# Altium schematic sheet XIMUF407.SchDoc(XIMUF407)
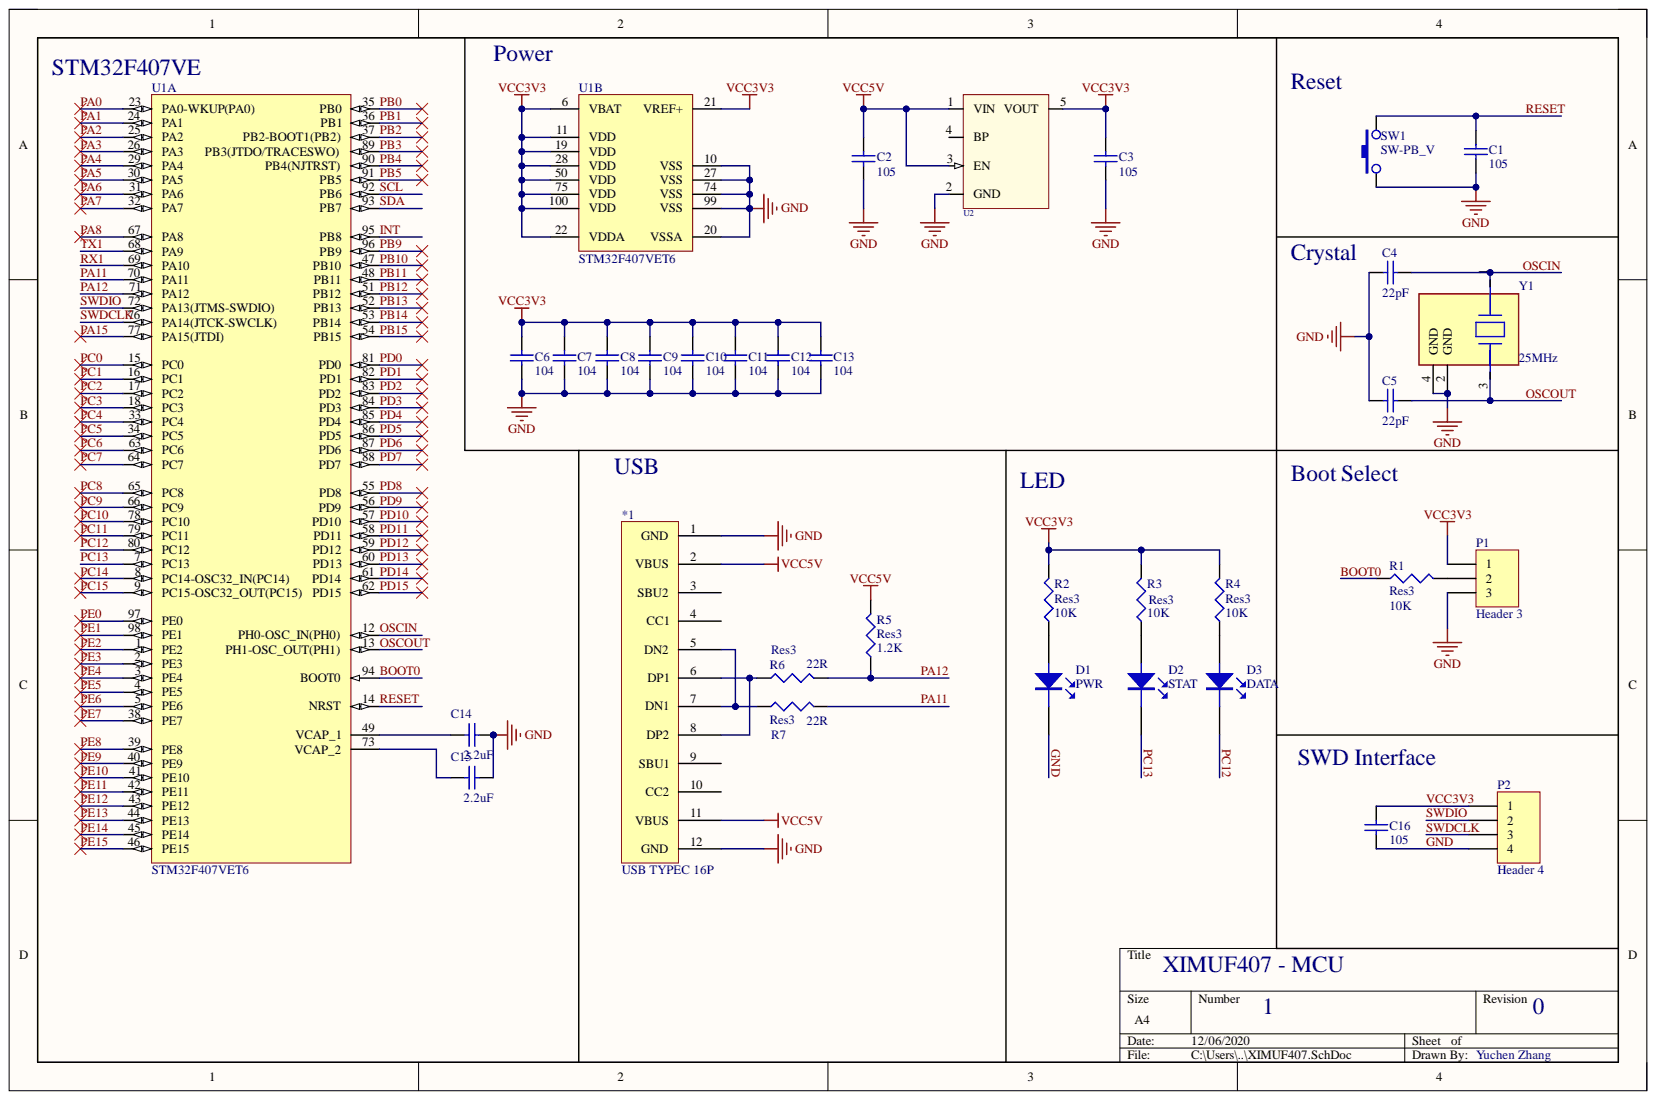
[diagram p0: XIMUF407.SchDoc]

## Parts
*1  USB TYPEC 16P  [New footprint 1]
C1  105  [C 0603]
C2  105  [C 0603]
C3  105  [C 0603]
C4  22pF  [C 0603]
C5  22pF  [C 0603]
C6  104  [C 0603]
C7  104  [C 0603]
C8  104  [C 0603]
C9  104  [C 0603]
C10  104  [C 0603]
C11  104  [C 0603]
C12  104  [C 0603]
C13  104  [C 0603]
C14  2.2uF  [C 0603]
C15  2.2uF  [C 0603]
C16  105  [C 0603]
D1  PWR  [LED 0805G]
D2  STAT  [LED 0805G]
D3  DATA  [LED 0805G]
P1  Header 3  [HDR1X3]
P2  Header 4  [HDR1X4]
R1  Res3  value 10K  [J1-0603]
R2  Res3  value 10K  [J1-0603]
R3  Res3  value 10K  [J1-0603]
R4  Res3  value 10K  [J1-0603]
R5  Res3  value 1.2K  [J1-0603]
R6  Res3  value 22R  [J1-0603]
R7  Res3  value 22R  [J1-0603]
SW1  SW-PB_V  [TSW SMD-3*6*2.5]
U1  STM32F407VET6  [STM-LQFP100_M]  (parts A,B)
U2  RT9193  [SOT23-5L]  (value not drawn)
Y1  25MHz  [FP-TSX-3225-MFG]

## Nets
VCC5V: *1.2(VBUS) *1.11(VBUS) C2.2 R5.2 U2.1(VIN) U2.3(EN)
BOOT0: R1.1 U1.94(BOOT0)
GND: *1.1(GND) *1.12(GND) C1.1 C2.1 C3.1 C4.2 C5.2 C6.1 C7.1 C8.1 C9.1 C10.1 C11.1 C12.1 C13.1 C14.1 C15.1 C16.1 D1.2 P1.3 P2.4 SW1.1 U1.10(VSS) U1.20(VSSA) U1.27(VSS) U1.74(VSS) U1.99(VSS) U2.2(GND) Y1.2(GND) Y1.4(GND)
INT: U1.95(PB8)
OSCIN: C4.1 U1.12(PH0-OSC_IN(PH0)) Y1.1
OSCOUT: C5.1 U1.13(PH1-OSC_OUT(PH1)) Y1.3
PA0: U1.23(PA0-WKUP(PA0))
PA1: U1.24(PA1)
PA2: U1.25(PA2)
PA3: U1.26(PA3)
PA4: U1.29(PA4)
PA5: U1.30(PA5)
PA6: U1.31(PA6)
PA7: U1.32(PA7)
PA8: U1.67(PA8)
PA11: R7.2 U1.70(PA11)
PA12: R5.1 R6.2 U1.71(PA12)
PA15: U1.77(PA15(JTDI))
PB0: U1.35(PB0)
PB1: U1.36(PB1)
PB2: U1.37(PB2-BOOT1(PB2))
PB3: U1.89(PB3(JTDO/TRACESWO))
PB4: U1.90(PB4(NJTRST))
PB5: U1.91(PB5)
PB9: U1.96(PB9)
PB10: U1.47(PB10)
PB11: U1.48(PB11)
PB12: U1.51(PB12)
PB13: U1.52(PB13)
PB14: U1.53(PB14)
PB15: U1.54(PB15)
PC0: U1.15(PC0)
PC1: U1.16(PC1)
PC2: U1.17(PC2)
PC3: U1.18(PC3)
PC4: U1.33(PC4)
PC5: U1.34(PC5)
PC6: U1.63(PC6)
PC7: U1.64(PC7)
PC8: U1.65(PC8)
PC9: U1.66(PC9)
PC10: U1.78(PC10)
PC11: U1.79(PC11)
PC12: D3.2 U1.80(PC12)
PC13: D2.2 U1.7(PC13)
PC14: U1.8(PC14-OSC32_IN(PC14))
PC15: U1.9(PC15-OSC32_OUT(PC15))
PD0: U1.81(PD0)
PD1: U1.82(PD1)
PD2: U1.83(PD2)
PD3: U1.84(PD3)
PD4: U1.85(PD4)
PD5: U1.86(PD5)
PD6: U1.87(PD6)
PD7: U1.88(PD7)
PD8: U1.55(PD8)
PD9: U1.56(PD9)
PD10: U1.57(PD10)
PD11: U1.58(PD11)
PD12: U1.59(PD12)
PD13: U1.60(PD13)
PD14: U1.61(PD14)
PD15: U1.62(PD15)
PE0: U1.97(PE0)
PE1: U1.98(PE1)
PE2: U1.1(PE2)
PE3: U1.2(PE3)
PE4: U1.3(PE4)
PE5: U1.4(PE5)
PE6: U1.5(PE6)
PE7: U1.38(PE7)
PE8: U1.39(PE8)
PE9: U1.40(PE9)
PE10: U1.41(PE10)
PE11: U1.42(PE11)
PE12: U1.43(PE12)
PE13: U1.44(PE13)
PE14: U1.45(PE14)
PE15: U1.46(PE15)
RESET: C1.2 SW1.2 U1.14(NRST)
RX1: U1.69(PA10)
SCL: U1.92(PB6)
SDA: U1.93(PB7)
SWDCLK: P2.3 U1.76(PA14(JTCK-SWCLK))
SWDIO: P2.2 U1.72(PA13(JTMS-SWDIO))
TX1: U1.68(PA9)
VCC3V3: C3.2 C6.2 C7.2 C8.2 C9.2 C10.2 C11.2 C12.2 C13.2 C16.2 P1.1 P2.1 R2.2 R3.2 R4.2 U1.6(VBAT) U1.11(VDD) U1.19(VDD) U1.21(VREF+) U1.22(VDDA) U1.28(VDD) U1.50(VDD) U1.75(VDD) U1.100(VDD) U2.5(VOUT)
Net*1_5: *1.5(DN2) *1.7(DN1) R7.1
Net*1_6: *1.6(DP1) *1.8(DP2) R6.1
NetC14_2: C14.2 U1.49(VCAP_1)
NetC15_2: C15.2 U1.73(VCAP_2)
NetD1_1: D1.1 R2.1
NetD2_1: D2.1 R3.1
NetD3_1: D3.1 R4.1
NetP1_2: P1.2 R1.2

Not connected: *1 3(SBU2) 4(CC1) 9(SBU1) 10(CC2); U2 4(BP)

## Library data of the parts
*1 = CMP-002-00073-3: Component Kind: Standard; Library Name: Yuchen Zhang
C1-C5 C14-C16 = Cap: Value: 104; Component Kind: Standard; LatestRevisionDate: 17-Jul-2002; LatestRevisionNote: Re-released for DXP Platform.; Library Name: stm32原理图库.SCHLIB; Published: 8-Jun-2000; Signal Integrity: Cap; Simulation: CAP; Publisher: Altium Limited
C6-C13 = Cap: Component Kind: Standard; LatestRevisionDate: 17-Jul-2002; LatestRevisionNote: Re-released for DXP Platform.; Library Name: stm32原理图库.SCHLIB; Published: 8-Jun-2000; Signal Integrity: Cap; Simulation: CAP; Value: 104; Publisher: Altium Limited
D1-D3 = LED: Component Kind: Standard; Library Name: stm32原理图库.SCHLIB
P1 = Header 3: Header, 3-Pin; Component Kind: Standard; LatestRevisionDate: 17-Jul-2002; LatestRevisionNote: Re-released for DXP Platform.; Library Name: Miscellaneous Connectors.IntLib; Signal Integrity: Connector; Publisher: Altium Limited
P2 = Header 4: Header, 4-Pin; Component Kind: Standard; LatestRevisionDate: 17-Jul-2002; LatestRevisionNote: Re-released for DXP Platform.; Library Name: Miscellaneous Connectors.IntLib; Signal Integrity: Connector; Publisher: Altium Limited
R1-R7 = Res3: Resistor; Component Kind: Standard; LatestRevisionDate: 29-May-2009; LatestRevisionNote: IPC-7351 Footprint Added.; Library Name: Miscellaneous Devices.IntLib; PackageDescription: Chip Resistor; PackageReference: J1-0603; Published: 8-Jun-2000; Signal Integrity: Res; Simulation: RESISTOR; Publisher: Altium Limited
U1 = CMP-0243-00016-3: ARM Cortex-M4 32-bit MCU+FPU, 210 DMIPS, 512 kB Flash, 192 kB Internal RAM, 82 I/Os, 100-pin LQFP, -40 to 85 degC, Tray; Manufacturer: STMicroelectronics; D/A Converter: 2x12-bit; FLASH Size (Prog) (kB): 512; I/Os (High Current): 82; Internal RAM Size (kB): 192; Operating Frequency(F) (Processor speed) (MHz): 168; Supply Voltage(Vcc) max (V): 3.6; Supply Voltage(Vcc) min (V): 1.8; Component Kind: Standard; ComponentLink1Description: Manufacturer URL; ComponentLink1URL: http://www.st.com/web/en/home.html; ComponentLink2Description: Datasheet; ComponentLink2URL: http://www.st.com/st-web-ui/static/active/en/resource/technical/document/datasheet/DM00037051.pdf; ComponentLink3Description: Package Specification; ComponentLink3URL: http://www.st.com/st-web-ui/static/active/en/resource/technical/document/data_brief/DM00071938.pdf; Core: ARM Cortex-M4; DatasheetVersion: Rev. 4; Library Name: Altium Content Vault; Mounting Technology: Surface Mount; PackageDescription: 100-Pin Low Profile Quad Flat Package, 14 x 14 mm Body, 0.5 mm Pitch; PackageReference: LQFP100; PackageVersion: Rev. 1, 06/2013; Packing: Tray; PartNumber: STM32F407VET6
U2 = RT9193X_5P: ICA_MFR_NAME: RICHTEK; ICA_PARTNUM: $$2191094$$RT9193-15CU5; Part Number: TMP-13; Component Kind: Standard; Library Name: stm32原理图库.SCHLIB
Y1 = CMP-36405-000005-1: CRYSTAL 16.00 MHZ 9PF SMD; Manufacturer: EPSON; Manufacturer Part Number: TSX-3225 16.0000MF18X-AC0; Esr: 60Ω; Frequency: 16MHz; Stability: 18ppm; Tolerance: 10ppm; Automotive: No; Category: Crys; Component Kind: Standard; ComponentLink2Description: Datasheet; ComponentLink2URL: https://support.epson.biz/td/api/doc_check.php?dl=brief_TSX-3225&lang=en; Device Class L1: Passive Components; Device Class L2: Crystals / Oscillators; Device Class L3: Crystals; Digikey Description: CRYSTAL 16.00 MHZ 9PF SMD; Height: 0.6mm; Lead Free: Yes; Library Name: Altium Content Vault; Load Capacitance: 9pF; Mouser Description: CRYSTAL 16.00 MHZ 9PF SMD; Octopart: https://octopart.com/tsx-3225+16.0000mf18x-ac0-epson-58756552; Package: TSX-3225; RoHS: TRUE; Series: TSX-3225; Temperature: -40°C to +85°C; Temperature Range High: +85°C; Temperature Range Low: -40°C
SW1 = SW-PB_V: Component Kind: Standard; Library Name: stm32原理图库.SCHLIB
